# Altium schematic sheet Detektor Žic, Detektor Vlažnosti.SchDoc
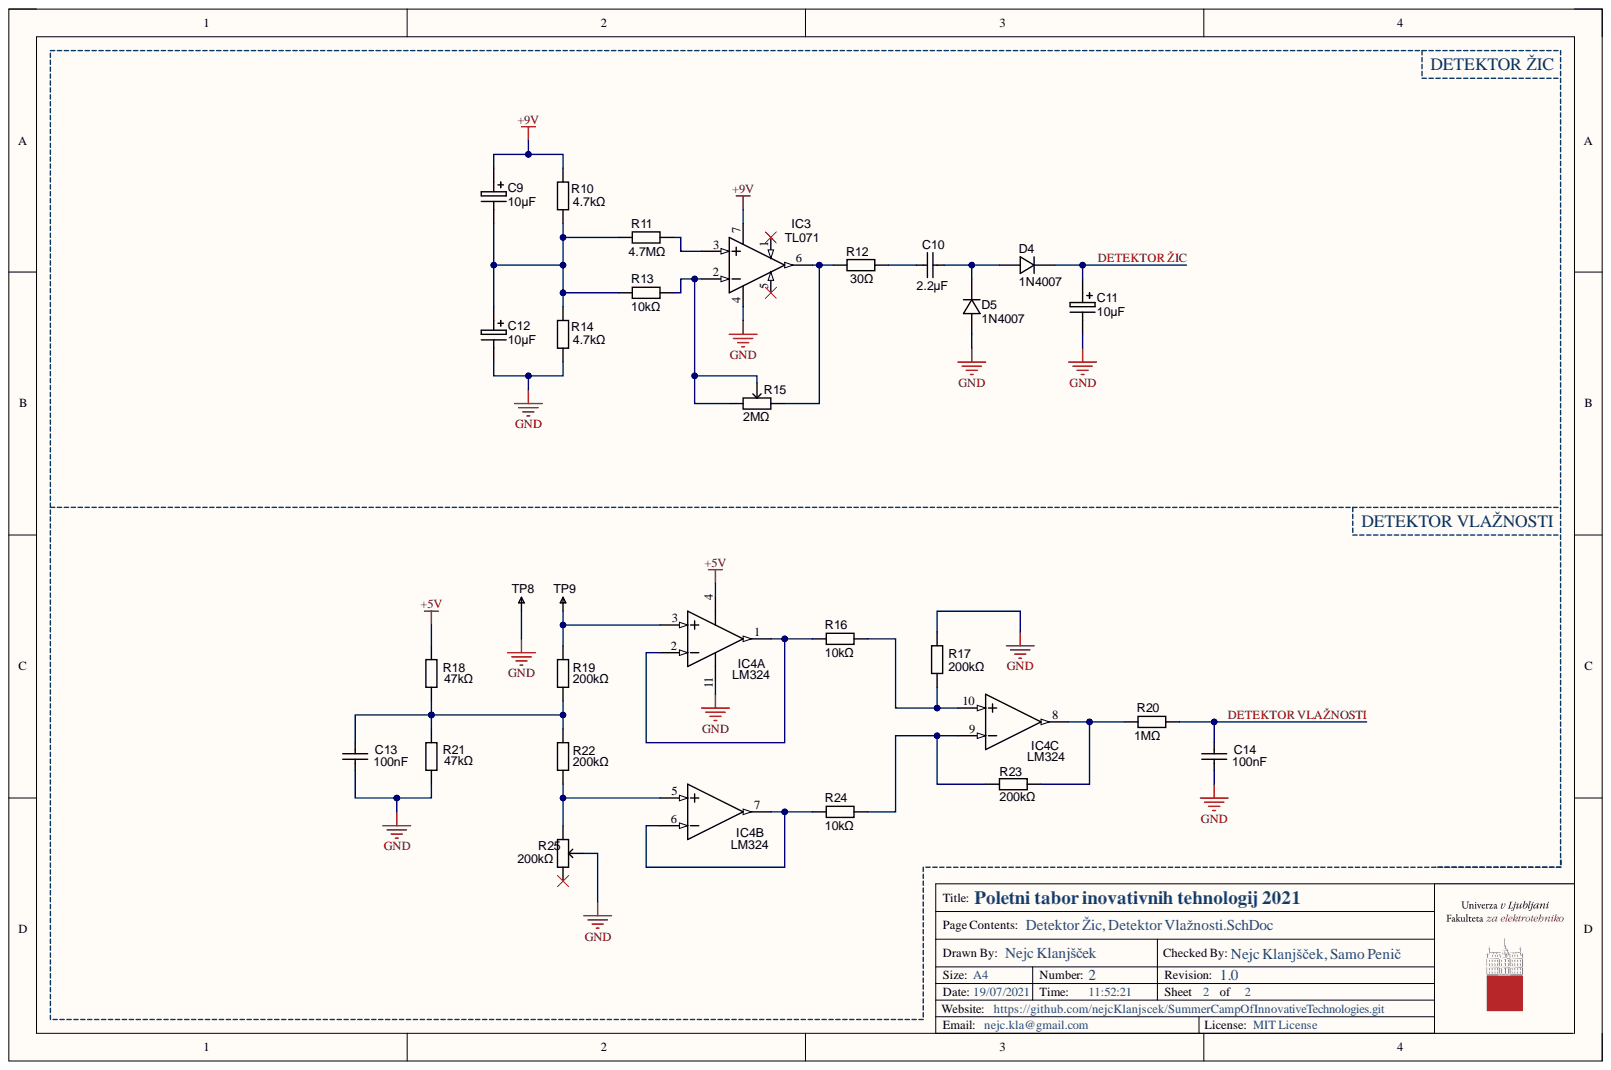
[diagram p0: Detektor Žic, Detektor Vlažnosti.SchDoc]

## Parts
C9  10μF  [CAPE_5x11x2LS]
C10  value 2.2μF  [B32520C0104J000]  (hidden comment: approved sub OK)
C11  10μF  [CAPE_5x11x2LS]
C12  10μF  [CAPE_5x11x2LS]
C13  value 100nF  [B32520C0104J000]  (hidden comment: approved sub OK)
C14  value 100nF  [B32520C0104J000]  (hidden comment: approved sub OK)
D4  1N4007  [DO-41]
D5  1N4007  [DO-41]
IC3  TL071  [DIP8-300]
IC4  LM324  [DIP794W66P254L1954H508Q14N]  (parts A,B,C)
R10  value 4.7kΩ  [RESTH_AXIAL-0.4]  (hidden comment: Carbon Film)
R11  value 4.7MΩ  [RESTH_AXIAL-0.4]  (hidden comment: Carbon Film)
R12  value 30Ω  [RESTH_AXIAL-0.4]  (hidden comment: Carbon Film)
R13  value 10kΩ  [RESTH_AXIAL-0.4]  (hidden comment: Carbon Film)
R14  value 4.7kΩ  [RESTH_AXIAL-0.4]  (hidden comment: Carbon Film)
R15  value 2MΩ  [3386F1153]  (hidden comment: Single-turn pot)
R16  value 10kΩ  [RESTH_AXIAL-0.4]  (hidden comment: Carbon Film)
R17  value 200kΩ  [RESTH_AXIAL-0.4]  (hidden comment: Carbon Film)
R18  value 47kΩ  [RESTH_AXIAL-0.4]  (hidden comment: Carbon Film)
R19  value 200kΩ  [RESTH_AXIAL-0.4]  (hidden comment: Carbon Film)
R20  value 1MΩ  [RESTH_AXIAL-0.4]  (hidden comment: Carbon Film)
R21  value 47kΩ  [RESTH_AXIAL-0.4]  (hidden comment: Carbon Film)
R22  value 200kΩ  [RESTH_AXIAL-0.4]  (hidden comment: Carbon Film)
R23  value 200kΩ  [RESTH_AXIAL-0.4]  (hidden comment: Carbon Film)
R24  value 10kΩ  [RESTH_AXIAL-0.4]  (hidden comment: Carbon Film)
R25  value 200kΩ  [3386P1103TLF]  (hidden comment: Single-turn pot)
TP8  TESTPOINT_THT  [TP-THT-MULTI]  (value not drawn)
TP9  TESTPOINT_THT  [TP-THT-MULTI]  (value not drawn)

## Nets
+5V: IC4.4 R18.2
+9V: C9.1 IC3.7 R10.2
GND: C11.2 C12.2 C13.1 C14.1 D5.A IC3.4 IC4.11 R14.1 R17.1 R21.1 R25.2 TP8.1
DETEKTOR VLAŽNOSTI: C14.2 R20.2
DETEKTOR ŽIC: C11.1 D4.K
NetC9_2: C9.2 C12.1 R10.1 R11.1 R13.1 R14.2
NetC10_1: C10.1 R12.2
NetC10_2: C10.2 D4.A D5.K
NetC13_2: C13.2 R18.1 R19.1 R21.2 R22.2
NetIC3_2: IC3.2 R13.2 R15.2 R15.3
NetIC3_3: IC3.3 R11.2
NetIC3_6: IC3.6 R12.1 R15.1
NetIC4_1: IC4.1 IC4.2 R16.1
NetIC4_3: IC4.3 R19.2 TP9.1
NetIC4_5: IC4.5 R22.1 R25.3
NetIC4_6: IC4.6 IC4.7 R24.1
NetIC4_8: IC4.8 R20.1 R23.1
NetIC4_9: IC4.9 R23.2 R24.2
NetIC4_10: IC4.10 R16.2 R17.2

Not connected: IC3 1 5; R25 1

## Library data of the parts
C9 C11 C12 = CAPT_10u_5mm_63V: Component Kind: Standard; Library Name: Nejc Klanjšček.IntLib
C10 = CAPC_220n_B32520: Capacitor THD Cer 220nF 10% 100V X7R B32520; Manufacturer 1: TDK Corporation; Manufacturer Part Number 1: C1608X7R1C224K; Ratings: 16V; X7R; Component Kind: Standard; Package / Case: 0603 (1608 metric); Supplier 1: Digi-Key; Supplier Part Number 1: 445-1318-1-ND; Tolerance: ±10%; Library Name: Nejc Klanjšček.IntLib
C13 C14 = CAPC_220n_B32520: Capacitor THD Cer 100nF 10% 100V X7R B32520; Manufacturer 1: TDK Corporation; Manufacturer Part Number 1: C1608X7R1C224K; Ratings: 16V; X7R; Component Kind: Standard; Package / Case: 0603 (1608 metric); Supplier 1: Digi-Key; Supplier Part Number 1: 445-1318-1-ND; Tolerance: ±10%; Library Name: Nejc Klanjšček.IntLib
D4 D5 = DIODE_1N4007_1000V_1.0A_DO-41: Diode 1N4007 1A 1000V THD; Manufacturer 1: Diodes Inc; Manufacturer Part Number 1: 1N4007-T; Diode Type: Standard; Ratings: 100V; 1.5A; Value: Vf = 1.15V @ 1.5A (Max); Component Kind: Standard; Mounting Type: Through Hole; Package / Case: DO-41; Library Name: Nejc Klanjšček.IntLib
IC3 = IC_OP-Amp_TL071: Component Kind: Standard; Library Name: Nejc Klanjšček.IntLib
IC4 = IC_OP-Amp_LM324: Component Kind: Standard; Library Name: Nejc Klanjšček.IntLib
R10 R14 = RES_12K_Axial_0.25W_5%: Resistor THD Axial 4.7kΩ 0.25W 5% Carbon Film; Manufacturer 1: Yageo; Manufacturer Part Number 1: CFR-25JB-12K; Ratings: 1/4W; Component Kind: Standard; Package / Case: Axial; Supplier 1: Digi-Key; Supplier Part Number 1: 12KQBK-ND; Tolerance: ±5%; Library Name: Nejc Klanjšček.IntLib
R11 = RES_12K_Axial_0.25W_5%: Resistor THD Axial 4.7MΩ 0.25W 5% Carbon Film; Manufacturer 1: Yageo; Manufacturer Part Number 1: CFR-25JB-12K; Ratings: 1/4W; Component Kind: Standard; Package / Case: Axial; Supplier 1: Digi-Key; Supplier Part Number 1: 12KQBK-ND; Tolerance: ±5%; Library Name: Nejc Klanjšček.IntLib
R12 = RES_12K_Axial_0.25W_5%: Resistor THD Axial 30Ω 0.25W 5% Carbon Film; Manufacturer 1: Yageo; Manufacturer Part Number 1: CFR-25JB-12K; Ratings: 1/4W; Component Kind: Standard; Package / Case: Axial; Supplier 1: Digi-Key; Supplier Part Number 1: 12KQBK-ND; Tolerance: ±5%; Library Name: Nejc Klanjšček.IntLib
R13 R16 R24 = RES_12K_Axial_0.25W_5%: Resistor THD Axial 10kΩ 0.25W 5% Carbon Film; Manufacturer 1: Yageo; Manufacturer Part Number 1: CFR-25JB-12K; Ratings: 1/4W; Component Kind: Standard; Package / Case: Axial; Supplier 1: Digi-Key; Supplier Part Number 1: 12KQBK-ND; Tolerance: ±5%; Library Name: Nejc Klanjšček.IntLib
R15 = RES_TRIMMER_2MEG: Trimmer THD 2MΩ 200mW Single-turn; Manufacturer 1: Bourns Inc.; Manufacturer Part Number 1: 3306F-1-103; Ratings: 1/5W; Tolerance: ±25%; Component Kind: Standard; Material: Cermet; Package / Case: 8.10mm L x 6.81mm W x 7.62mm H; Supplier 1: Digi-Key; Supplier Part Number 1: 3306F-103-ND; Library Name: Nejc Klanjšček.IntLib
R17 R19 R22 R23 = RES_12K_Axial_0.25W_5%: Resistor THD Axial 200kΩ 0.25W 5% Carbon Film; Manufacturer 1: Yageo; Manufacturer Part Number 1: CFR-25JB-12K; Ratings: 1/4W; Component Kind: Standard; Package / Case: Axial; Supplier 1: Digi-Key; Supplier Part Number 1: 12KQBK-ND; Tolerance: ±5%; Library Name: Nejc Klanjšček.IntLib
R18 R21 = RES_12K_Axial_0.25W_5%: Resistor THD Axial 47kΩ 0.25W 5% Carbon Film; Manufacturer 1: Yageo; Manufacturer Part Number 1: CFR-25JB-12K; Ratings: 1/4W; Component Kind: Standard; Package / Case: Axial; Supplier 1: Digi-Key; Supplier Part Number 1: 12KQBK-ND; Tolerance: ±5%; Library Name: Nejc Klanjšček.IntLib
R20 = RES_12K_Axial_0.25W_5%: Resistor THD Axial 1MΩ 0.25W 5% Carbon Film; Manufacturer 1: Yageo; Manufacturer Part Number 1: CFR-25JB-12K; Ratings: 1/4W; Component Kind: Standard; Package / Case: Axial; Supplier 1: Digi-Key; Supplier Part Number 1: 12KQBK-ND; Tolerance: ±5%; Library Name: Nejc Klanjšček.IntLib
R25 = RES_TRIMMER_200k: Trimmer THD 10K 200mW Single-turn; Manufacturer 1: Bourns Inc.; Manufacturer Part Number 1: 3306F-1-103; Ratings: 1/5W; Tolerance: ±25%; Component Kind: Standard; Material: Cermet; Package / Case: 8.10mm L x 6.81mm W x 7.62mm H; Supplier 1: Digi-Key; Supplier Part Number 1: 3306F-103-ND; Library Name: Nejc Klanjšček.IntLib
TP8 TP9 = TESTPOINT_THT: Test Point PCB mount Multi-purpose Yellow; Manufacturer 1: Keystone Electronics; Manufacturer Part Number 1: 5014; Description 1: TEST POINT PC MULTI PURPOSE YEL; Component Kind: Standard; Material: Base, Nylon 46, UL 94V-0; Mounting Type: 0.063" (1.600mm) Hole Diameter; Supplier 1: Digi-Key; Supplier Part Number 1: 5014K-ND; Library Name: Nejc Klanjšček.IntLib
